# Altium schematic sheet CommandBoard.SchDoc
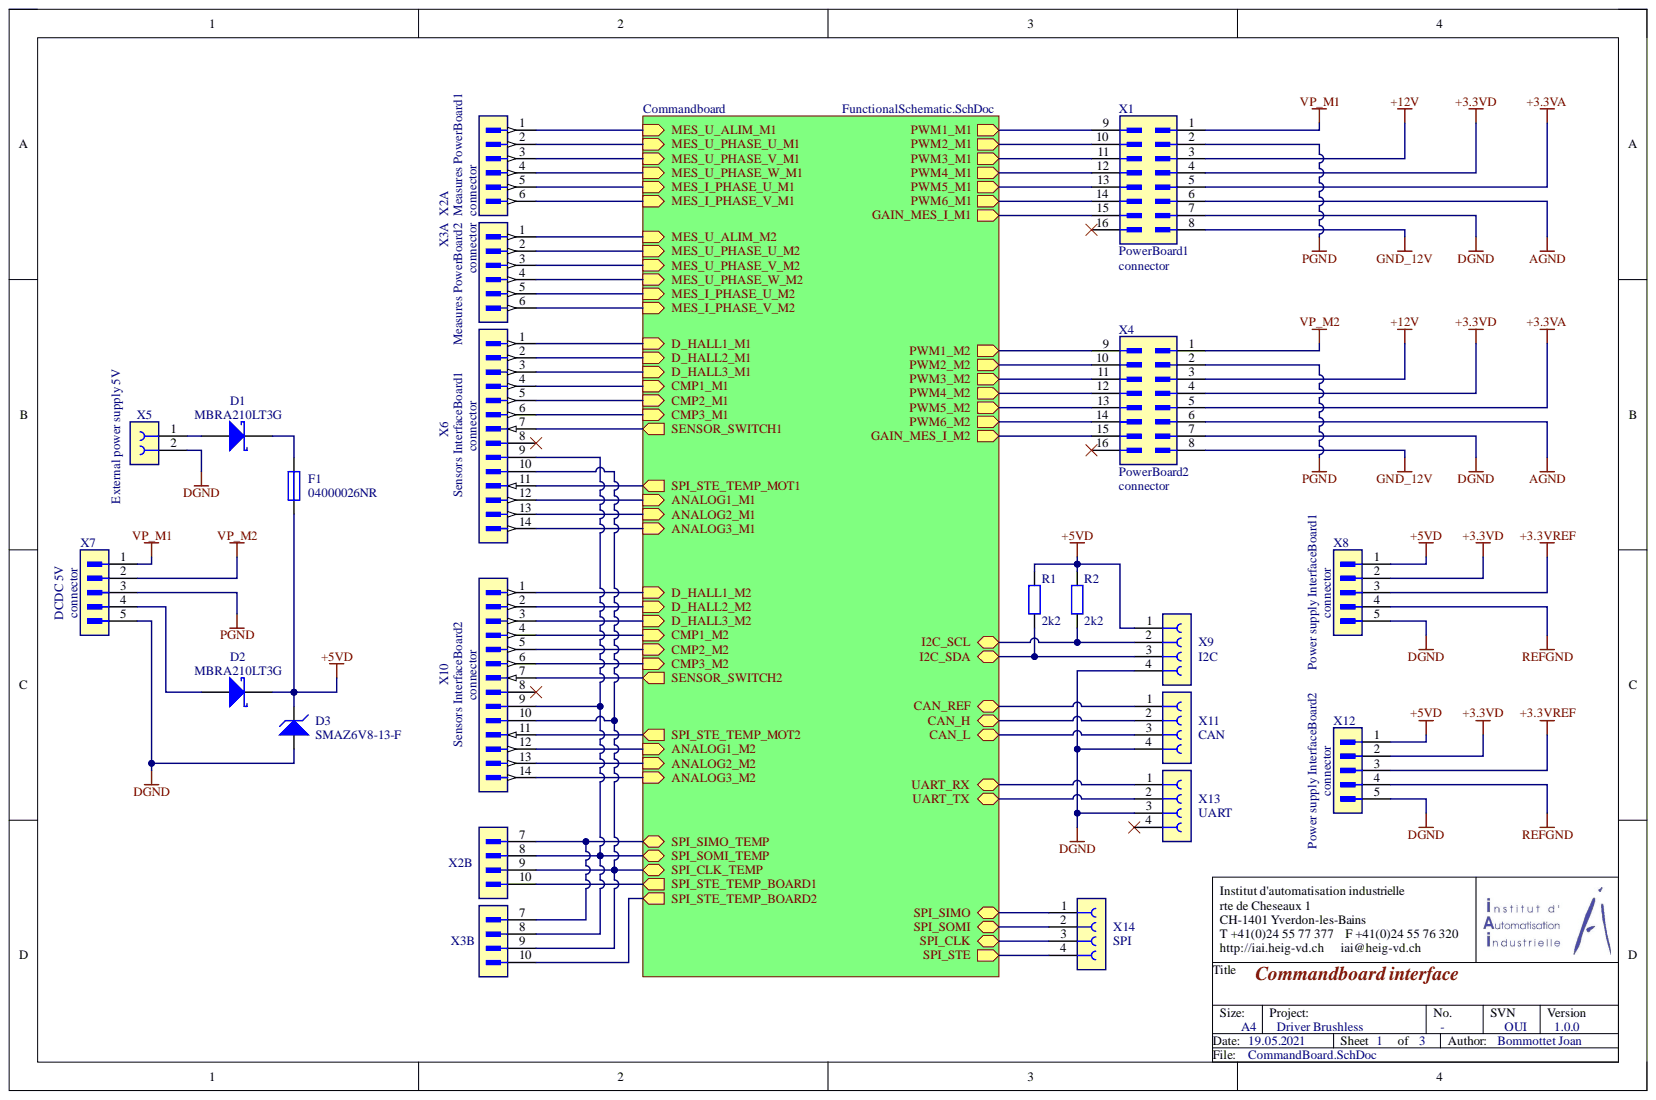
[diagram p0: CommandBoard.SchDoc]

## Parts
D1  value MBRA210LT3G  [DIOM4326X22N]  (hidden comment: DIODE SCHOTTKY 10V 2A SMA)
D2  value MBRA210LT3G  [DIOM4326X22N]  (hidden comment: DIODE SCHOTTKY 10V 2A SMA)
D3  value SMAZ6V8-13-F  [DIOM4326X22N]  (hidden comment: DIODE ZENER 6.8V 1W SMA)
F1  value 04000026NR  [FUSEC1508X06N]  (hidden comment: FUSE 1.5A 5V FAST 0603 SMD)
R1  value 2k2  [RESC1508X04N]  (hidden comment: RES SMD 2K2 0603)
R2  value 2k2  [RESC1508X04N]  (hidden comment: RES SMD 2K2 0603)
X1  system: connector  Use: PowerBoard1  [62101621021]  (hidden comment: CONNECT SMD 16pos 180°)
X2  system: connector  Use: Measures PowerBoard1  [62101021021]  (hidden comment: CONNECT SMD 10pos 180°)  (parts A,B)
X3  system: connector  Use: Measures PowerBoard2  [62101021021]  (hidden comment: CONNECT SMD 10pos 180°)  (parts A,B)
X4  system: connector  Use: PowerBoard2  [62101621021]  (hidden comment: CONNECT SMD 16pos 180°)
X5  CONNECT 2pos 90°  [WR-TBL322 3.81mm 2p]  (value not drawn)
X6  system: connector  Use: Sensors InterfaceBoard1  [62101421021]  (hidden comment: CONNECT SMD 16pos 180°)
X7  system: connector  Use: DCDC 5V  [62000611121]  (hidden comment: CONNECT SMD 5pos 180°)
X8  system: connector  Use: Power supply InterfaceBoard1  [62000611121]  (hidden comment: CONNECT SMD 5pos 180°)
X9  Use: I2C  [TE_7-215079-4]  (hidden comment: CONNECT SMD 4pos 180°)
X10  system: connector  Use: Sensors InterfaceBoard2  [62101421021]  (hidden comment: CONNECT SMD 16pos 180°)
X11  Use: CAN  [TE_7-215079-4]  (hidden comment: CONNECT SMD 4pos 180°)
X12  system: connector  Use: Power supply InterfaceBoard2  [62000611121]  (hidden comment: CONNECT SMD 5pos 180°)
X13  Use: UART  [TE_7-215079-4]  (hidden comment: CONNECT SMD 4pos 180°)
X14  Use: SPI  [TE_7-215079-4]  (hidden comment: CONNECT SMD 4pos 180°)

## Nets
+3.3VA: X1.5 X4.5
+3.3VD: X1.4 X4.4 X8.2 X12.2
+3.3VREF: X8.3 X12.3
+5VD: D2.2 D3.2 F1.1 R1.2 R2.2 X8.1 X9.1 X12.1
+12V: X1.3 X4.3
AGND: X1.6 X4.6
DGND: D3.1 X1.7 X4.7 X5.2 X7.5 X8.5 X9.4 X11.4 X12.5 X13.3
GND_12V: X1.8 X4.8
PGND: X1.2 X4.2 X7.3
REFGND: X8.4 X12.4
VP_M1: X1.1 X7.1
VP_M2: X4.1 X7.2
NetD1_1: D1.1 X5.1
NetD1_2: D1.2 F1.2
NetD2_1: D2.1 X7.4
NetR1_1: R1.1 X9.3
NetR2_1: R2.1 X9.2
NetX1_9: X1.9
NetX1_10: X1.10
NetX1_11: X1.11
NetX1_12: X1.12
NetX1_13: X1.13
NetX1_14: X1.14
NetX1_15: X1.15
NetX1_16: X1.16
NetX2_1: X2.1
NetX2_2: X2.2
NetX2_3: X2.3
NetX2_4: X2.4
NetX2_5: X2.5
NetX2_6: X2.6
NetX2_7: X2.7 X3.7
NetX2_8: X2.8 X3.8 X6.9 X10.9
NetX2_9: X2.9 X3.9 X6.10 X10.10
NetX2_10: X2.10
NetX3_1: X3.1
NetX3_2: X3.2
NetX3_3: X3.3
NetX3_4: X3.4
NetX3_5: X3.5
NetX3_6: X3.6
NetX3_10: X3.10
NetX4_9: X4.9
NetX4_10: X4.10
NetX4_11: X4.11
NetX4_12: X4.12
NetX4_13: X4.13
NetX4_14: X4.14
NetX4_15: X4.15
NetX4_16: X4.16
NetX6_1: X6.1
NetX6_2: X6.2
NetX6_3: X6.3
NetX6_4: X6.4
NetX6_5: X6.5
NetX6_6: X6.6
NetX6_7: X6.7
NetX6_8: X6.8
NetX6_11: X6.11
NetX6_12: X6.12
NetX6_13: X6.13
NetX6_14: X6.14
NetX10_1: X10.1
NetX10_2: X10.2
NetX10_3: X10.3
NetX10_4: X10.4
NetX10_5: X10.5
NetX10_6: X10.6
NetX10_7: X10.7
NetX10_8: X10.8
NetX10_11: X10.11
NetX10_12: X10.12
NetX10_13: X10.13
NetX10_14: X10.14
NetX11_1: X11.1
NetX11_2: X11.2
NetX11_3: X11.3
NetX13_1: X13.1
NetX13_2: X13.2
NetX13_4: X13.4
NetX14_1: X14.1
NetX14_2: X14.2
NetX14_3: X14.3
NetX14_4: X14.4

## Library data of the parts
D1 D2 = DIODE SCHOTTKY 10V 2A SMA: Diode Schottky 10V 2A Montage en surface SMA; Component Kind: Standard; ComponentLink1Description: Manufacturer Link; ComponentLink1URL: http://www.infineon.com/; ComponentLink2Description: Datasheet; ComponentLink2URL: http://www.infineon.com/upload/Document/cmc_upload/documents/009/363/bat17series.pdf; Supplier 1: Digi-Key; Supplier Part Number 1: MBRA210LT3GOSCT-ND; Library Name: CommandBoard.SchLib
D3 = DIODE ZENER 6.8V 1W SMA: Diodes - Zener 6,8V 1W ±5% Montage en surface SMA; Component Kind: Standard; Supplier 1: Digi-Key; Supplier Part Number 1: SMAZ6V8-FDICT-ND; Library Name: CommandBoard.SchLib
F1 = FUSE 1.5A 5V FAST 0603 SMD: 1,5A CA 5V Fusible CC Montage sur carte (type cartouche exclu) Montage en surface 0603 (1608 métrique); Component Kind: Standard; Supplier 1: Digi-Key; Supplier Part Number 1: F12132CT-ND; Library Name: CommandBoard.SchLib
R1 R2 = RES SMD 2K2 OHM 1% 1/10W 0603: 2,2 kOhms ±1% 0,1W, 1/10W Pavé résistif 0603 (1608 métrique) AutomobileAEC-Q200 Couche épaisse; Component Kind: Standard; Supplier 1: Digi-Key; Supplier Part Number 1: RMCF0603FT2K20CT-ND; Library Name: CommandBoard.SchLib
X1 X4 = CONNECT SMD 16pos 2row 180° 1.27mm: Component Kind: Standard; Library Name: CommandBoard.SchLib
X2 X3 = CONNECT SMD 10pos 180° 1.27mm: Component Kind: Standard; Library Name: CommandBoard.SchLib
X5 = CONNECT 2pos 90° 3.81mm F: 2 Positions, bloc de jonction Embase, broche mâle, gainée (4 côtés) 0,150 po (3,81mm) 90°, engle droit Trou traversant; Use: External power supply 5V; Value: WR-TBL322; Component Kind: Standard; Supplier 1: Digi-Key; Supplier Part Number 1: 732-2088-ND; Library Name: CommandBoard.SchLib
X6 X10 = CONNECT SMD 16pos 180° 1.27mm: Component Kind: Standard; Library Name: CommandBoard.SchLib
X7 X8 X12 = CONNECT SMD 5pos 180° 2mm: Component Kind: Standard; Library Name: CommandBoard.SchLib
X9 X11 X13 X14 = CONNECT SMD 4pos 180° 2.54mm F: Connecteur, embase Montage en surface 4 positions 0,100 po (2,54mm); Component Kind: Standard; Library Name: CommandBoard.SchLib
